# Altium schematic sheet SW6224+A+B+C+LED_普通188数码管.SchDoc
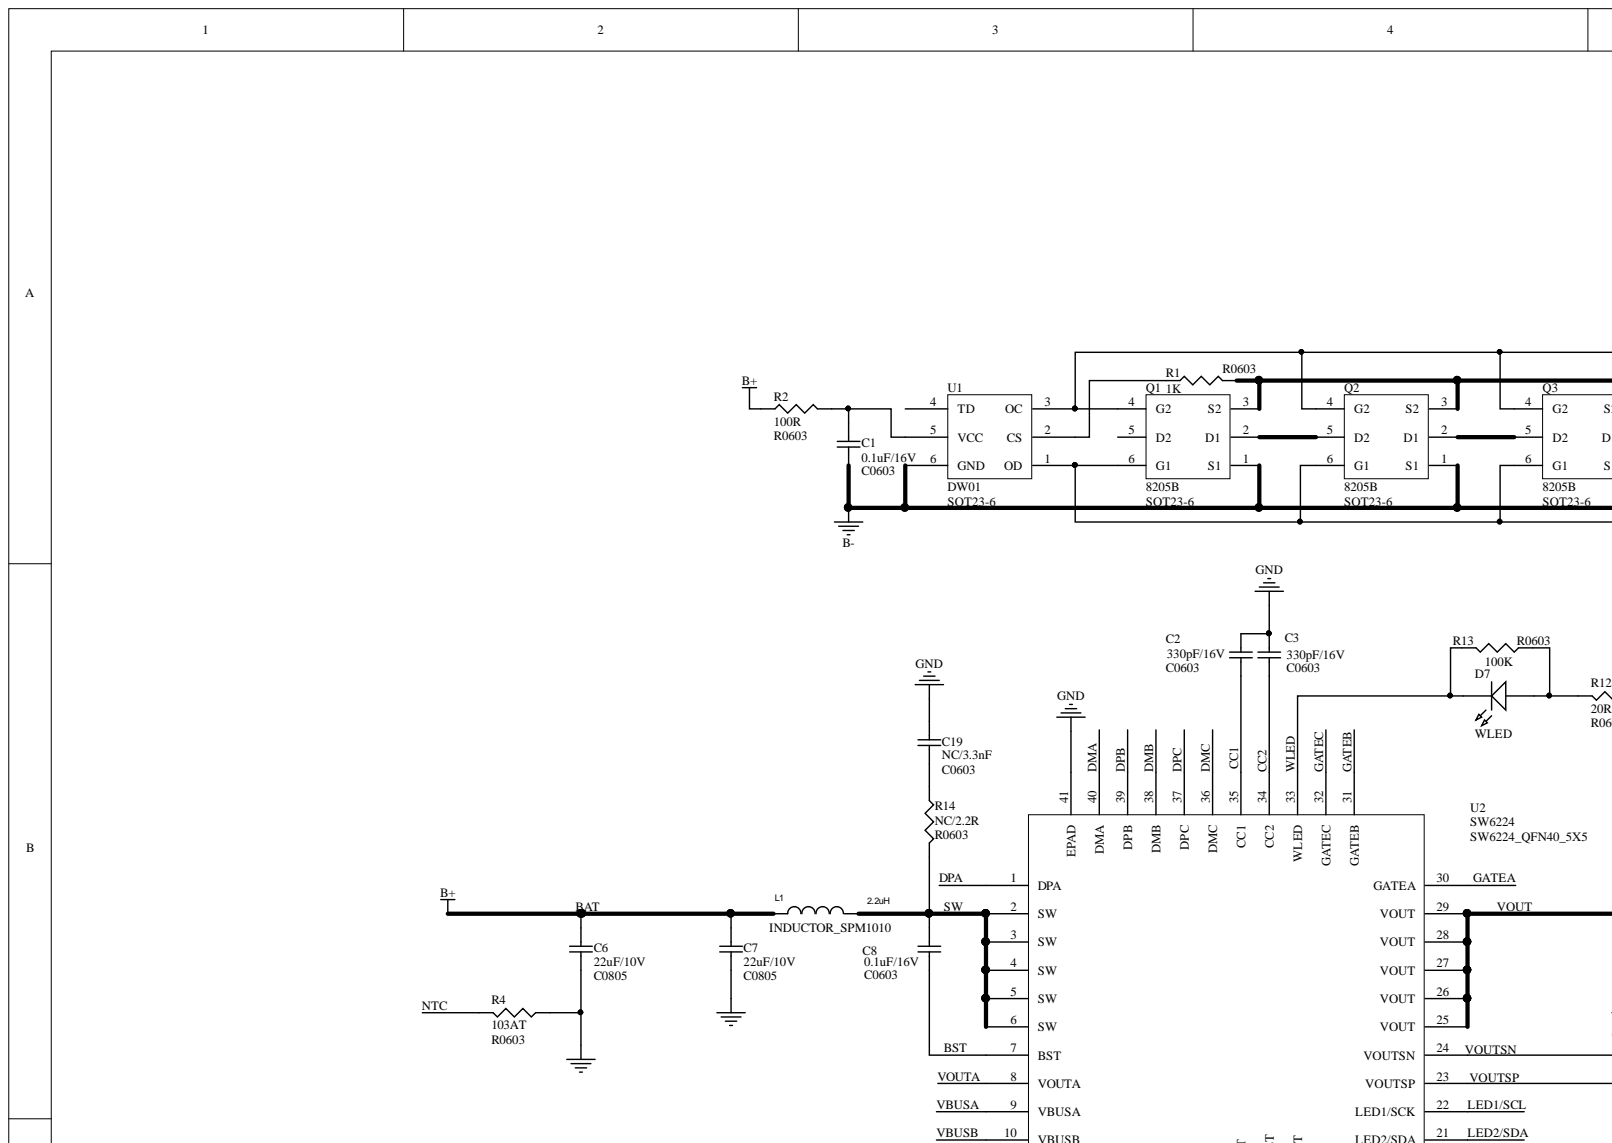
[diagram p0: SW6224+A+B+C+LED_普通188数码管.SchDoc, top left]
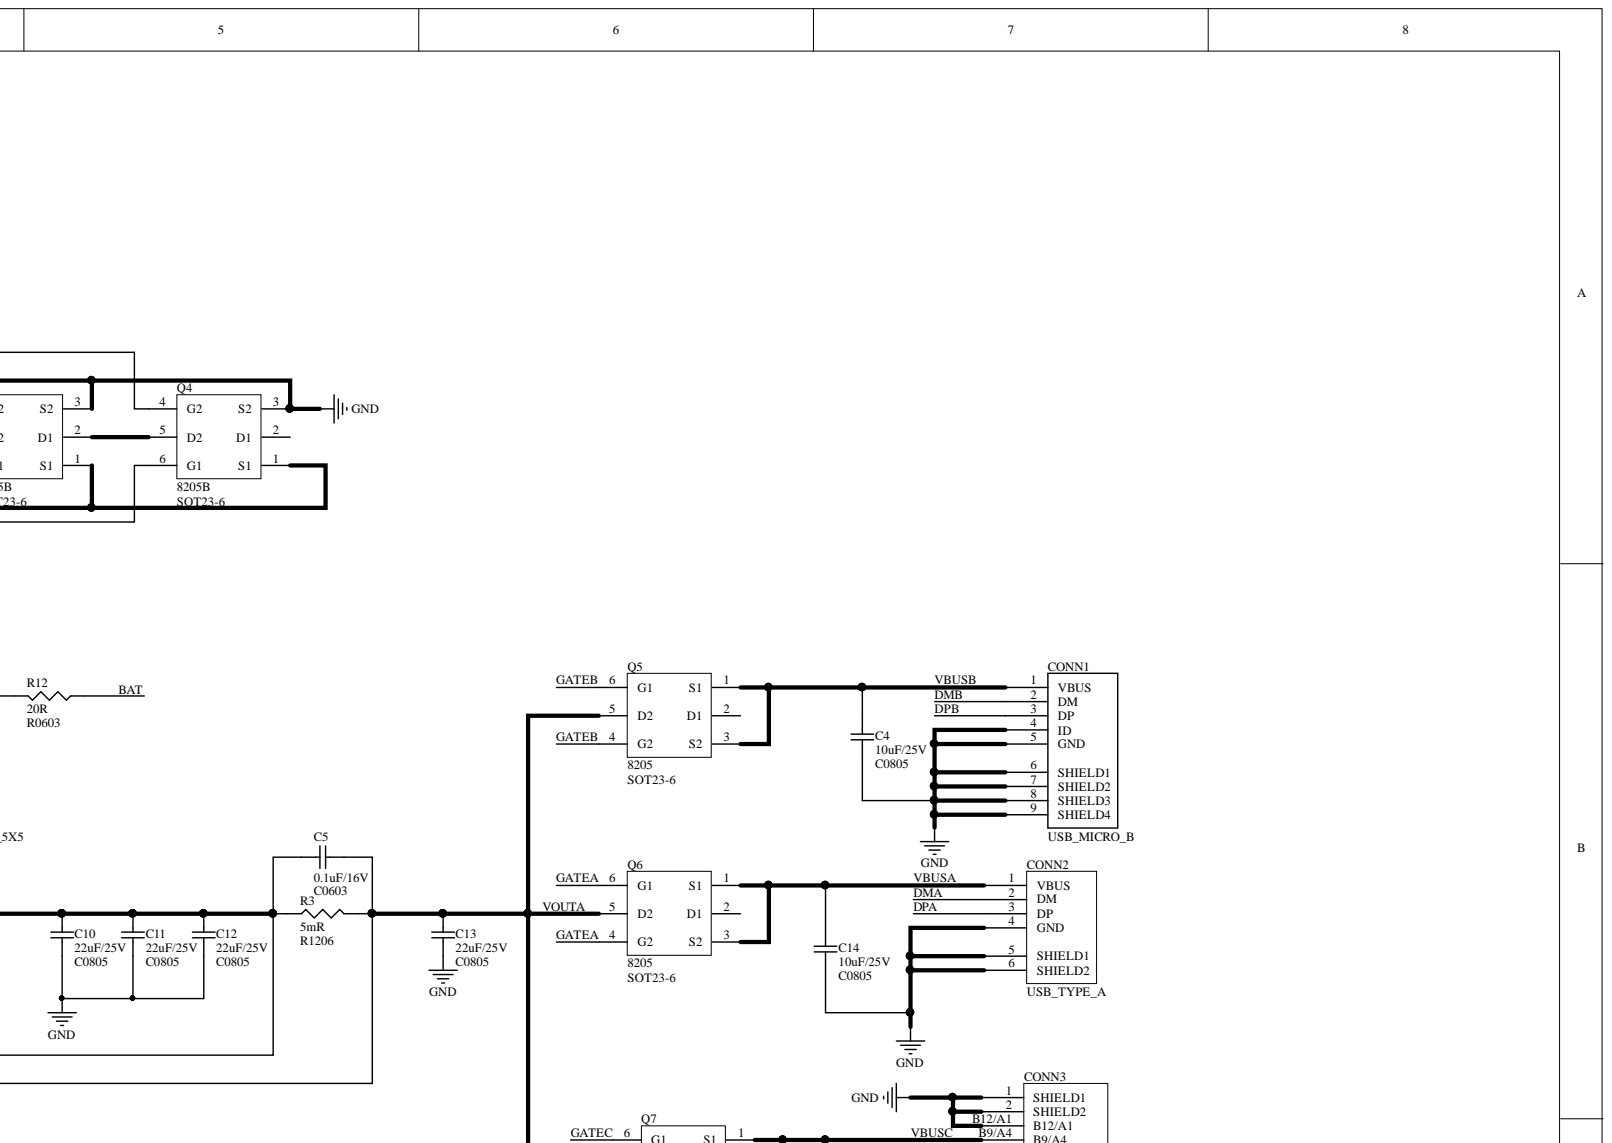
[diagram p1: SW6224+A+B+C+LED_普通188数码管.SchDoc, top right]
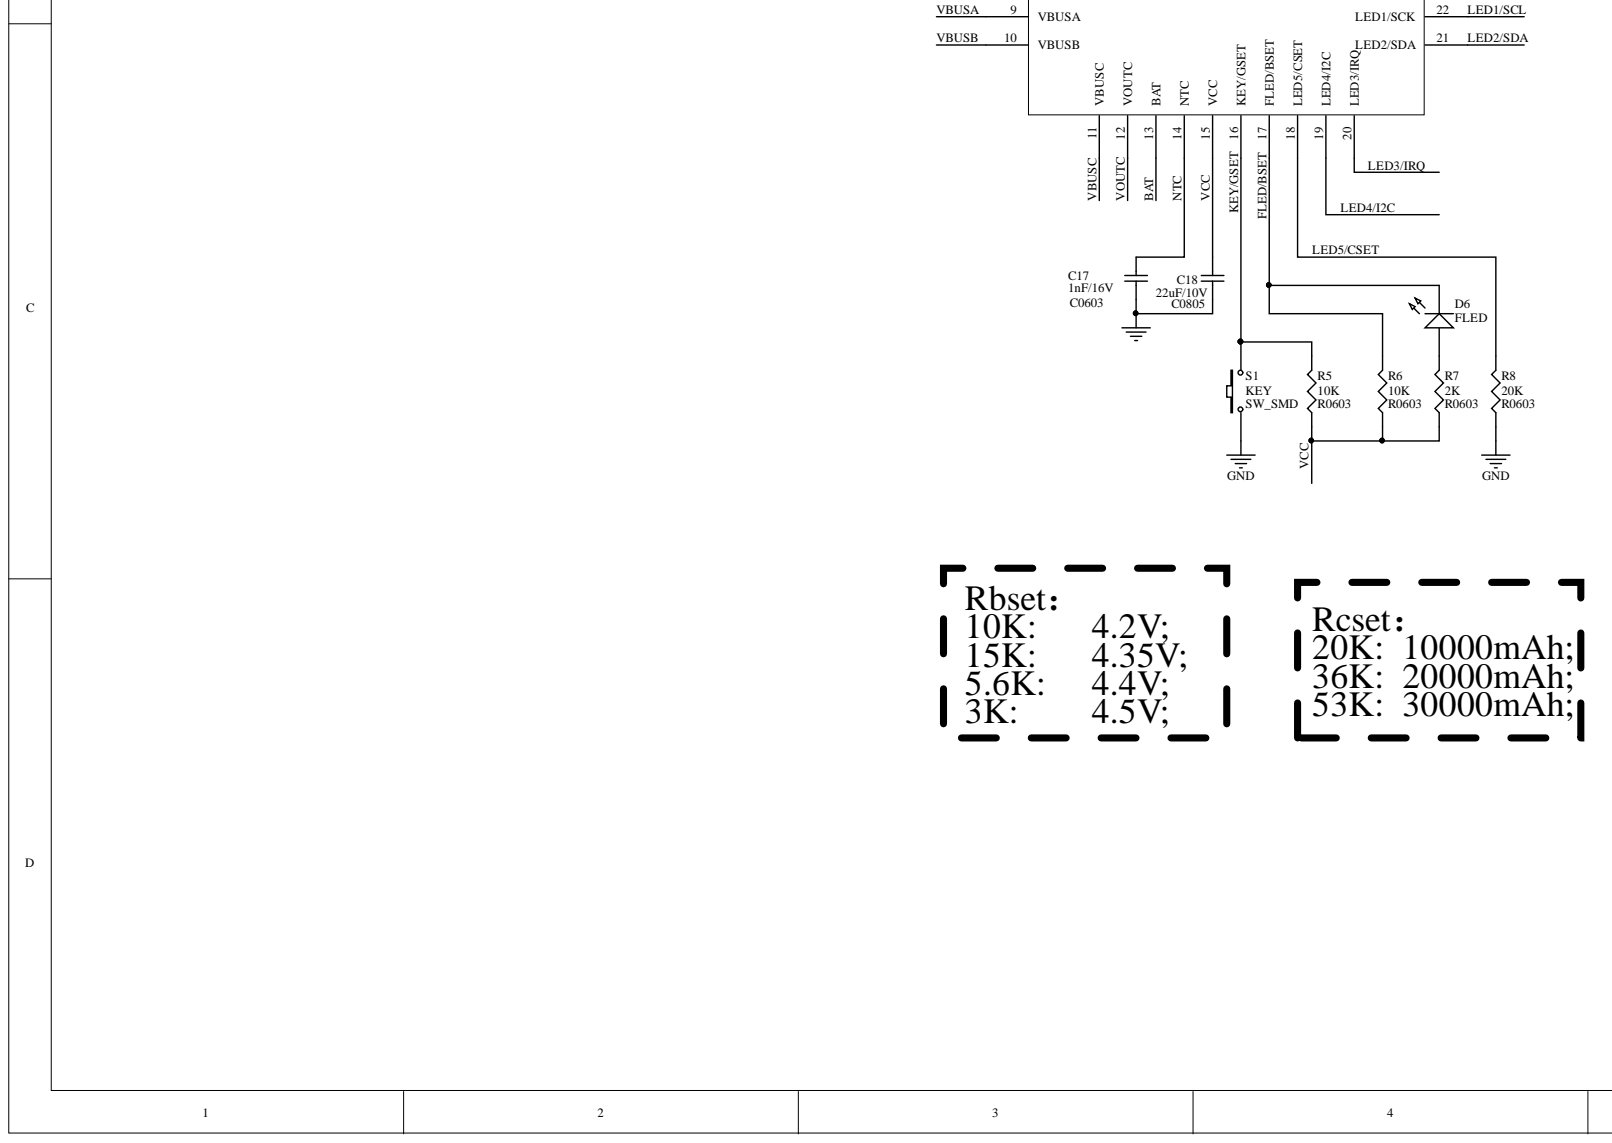
[diagram p2: SW6224+A+B+C+LED_普通188数码管.SchDoc, bottom left]
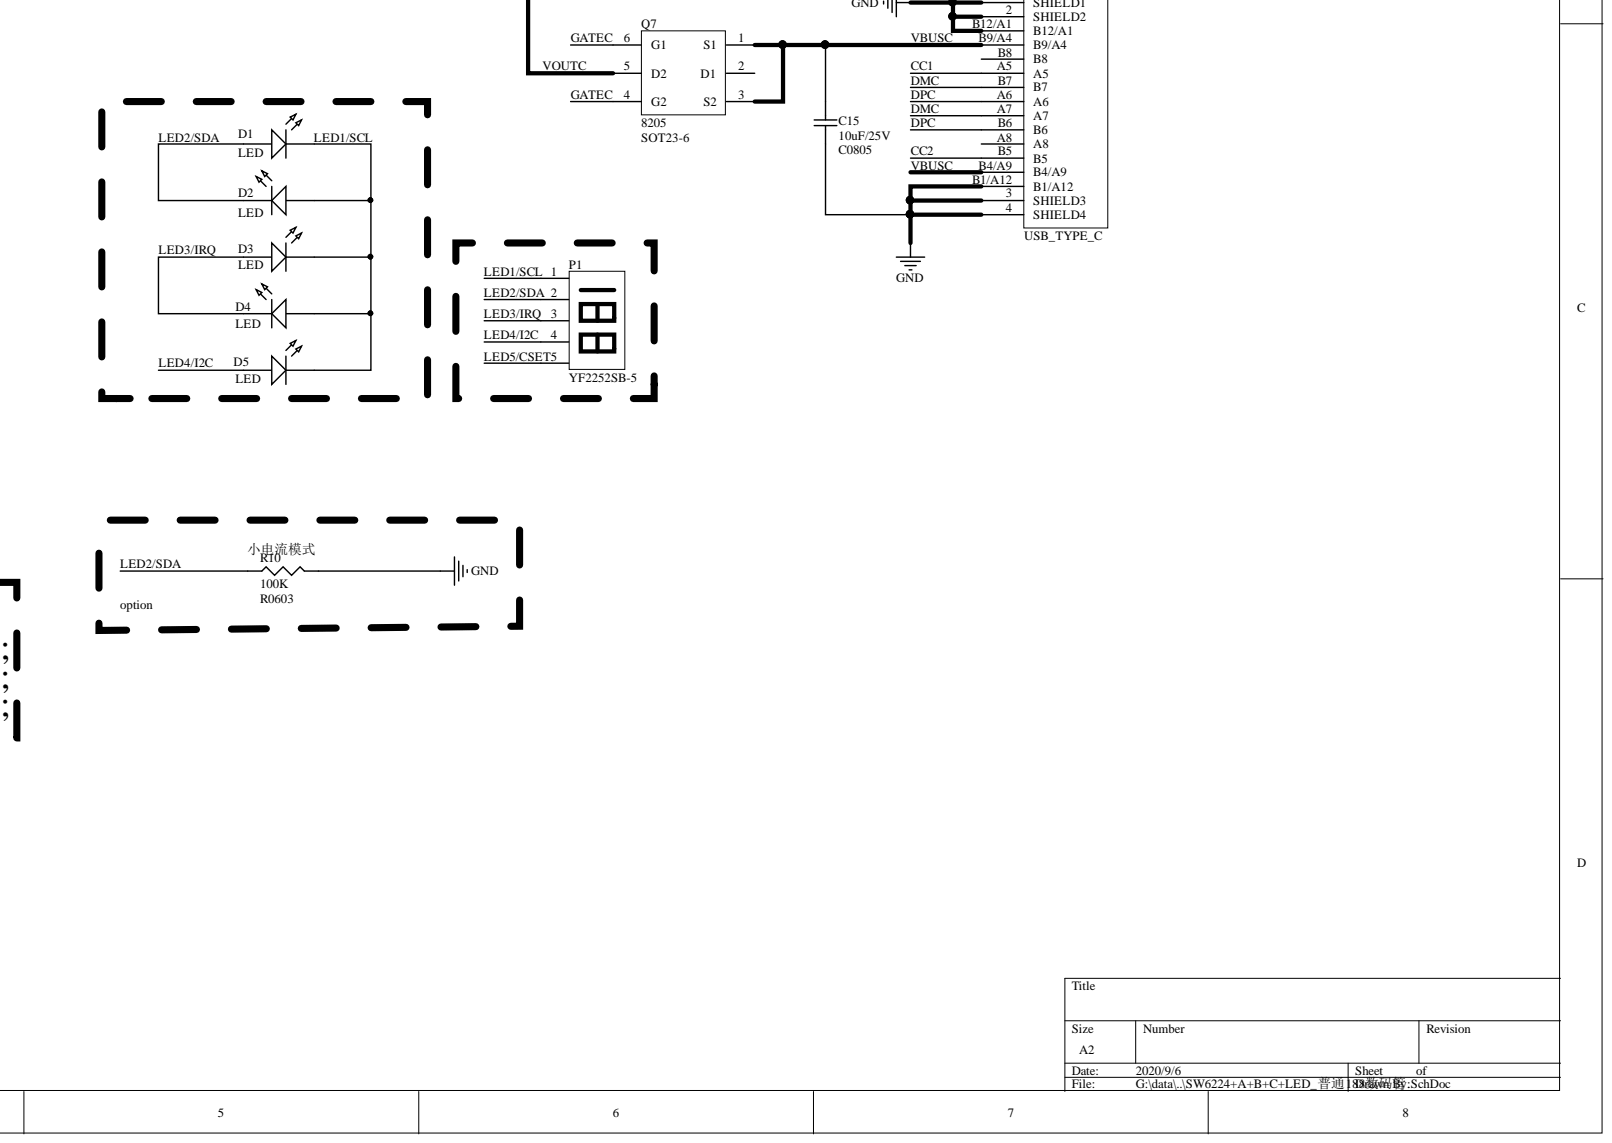
[diagram p3: SW6224+A+B+C+LED_普通188数码管.SchDoc, bottom right]

## Parts
C1  0.1uF/16V  [C0603]
C2  330pF/16V  [C0603]
C3  330pF/16V  [C0603]
C4  10uF/25V  [C0805]
C5  0.1uF/16V  [C0603]
C6  22uF/10V  [C0805]
C7  22uF/10V  [C0805]
C8  0.1uF/16V  [C0603]
C10  22uF/25V  [C0805]
C11  22uF/25V  [C0805]
C12  22uF/25V  [C0805]
C13  22uF/25V  [C0805]
C14  10uF/25V  [C0805]
C15  10uF/25V  [C0805]
C17  1nF/16V  [C0603]
C18  22uF/10V  [C0805]
C19  NC/3.3nF  [C0603]
CONN1  USB_MICRO_B  [USB_MICRO_B]
CONN2  USB_TYPE_A  [USB_TYPE_A]
CONN3  USB_TYPE_C  [USB_TYPE_C]
D1  LED  [LED0603]
D2  LED  [LED0603]
D3  LED  [LED0603]
D4  LED  [LED0603]
D5  LED  [LED0603]
D6  FLED  [LED0603]
D7  WLED  [LED_5MM]
L1  2.2uH  [INDUCTOR_SPM1010]
P1  YF2252SB-5  [LED_Display_5]
Q1  8205B  [SOT23-6]
Q2  8205B  [SOT23-6]
Q3  8205B  [SOT23-6]
Q4  8205B  [SOT23-6]
Q5  8205  [SOT23-6]
Q6  8205  [SOT23-6]
Q7  8205  [SOT23-6]
R1  1K  [R0603]
R2  100R  [R0603]
R3  5mR  [R1206]
R4  103AT  [R0603]
R5  10K  [R0603]
R6  10K  [R0603]
R7  2K  [R0603]
R8  20K  [R0603]
R10  100K  [R0603]
R12  20R  [R0603]
R13  100K  [R0603]
R14  NC/2.2R  [R0603]
S1  KEY  [SW_SMD]
U1  DW01  [SOT23-6]
U2  SW6224  [SW6224_QFN40_5X5]

## Nets
B-: C1.1 Q1.1(S1) Q2.1(S1) Q3.1(S1) Q4.1(S1) U1.6(GND)
GND: C2.2 C3.2 C4.1 C6.1 C7.1 C10.1 C11.1 C12.1 C13.1 C14.1 C15.1 C17.2 C18.2 C19.2 CONN1.4(ID) CONN1.5(GND) CONN1.6(SHIELD1) CONN1.7(SHIELD2) CONN1.8(SHIELD3) CONN1.9(SHIELD4) CONN2.4(GND) CONN2.5(SHIELD1) CONN2.6(SHIELD2) CONN3.1(SHIELD1) CONN3.2(SHIELD2) CONN3.3(SHIELD3) CONN3.4(SHIELD4) CONN3.B1/A12 CONN3.B12/A1 Q1.3(S2) Q2.3(S2) Q3.3(S2) Q4.3(S2) R1.2 R4.1 R8.1 R10.2 S1.1 U2.41(EPAD)
BAT: C6.2 C7.2 L1.1 R2.1 R12.1 U2.13(BAT)
BST: C8.1 U2.7(BST)
CC1: C2.1 CONN3.A5 U2.35(CC1)
CC2: C3.1 CONN3.B5 U2.34(CC2)
DMA: CONN2.2(DM) U2.40(DMA)
DMB: CONN1.2(DM) U2.38(DMB)
DMC: CONN3.A7 CONN3.B7 U2.36(DMC)
DPA: CONN2.3(DP) U2.1(DPA)
DPB: CONN1.3(DP) U2.39(DPB)
DPC: CONN3.A6 CONN3.B6 U2.37(DPC)
FLED/BSET: D6.2 R6.2 U2.17(FLED/BSET)
GATEA: Q6.4(G2) Q6.6(G1) U2.30(GATEA)
GATEB: Q5.4(G2) Q5.6(G1) U2.31(GATEB)
GATEC: Q7.4(G2) Q7.6(G1) U2.32(GATEC)
KEY/GSET: R5.2 S1.2 U2.16(KEY/GSET)
LED1/SCL: D1.2 D2.1 D3.2 D4.1 D5.2 P1.1 U2.22(LED1/SCK)
LED2/SDA: D1.1 D2.2 P1.2 R10.1 U2.21(LED2/SDA)
LED3/IRQ: D3.1 D4.2 P1.3 U2.20(LED3/IRQ)
LED4/I2C: D5.1 P1.4 U2.19(LED4/I2C)
LED5/CSET: P1.5 R8.2 U2.18(LED5/CSET)
NTC: C17.1 R4.2 U2.14(NTC)
SW: C8.2 L1.2 R14.2 U2.2(SW) U2.3(SW) U2.4(SW) U2.5(SW) U2.6(SW)
VBUSA: C14.2 CONN2.1(VBUS) Q6.1(S1) Q6.3(S2) U2.9(VBUSA)
VBUSB: C4.2 CONN1.1(VBUS) Q5.1(S1) Q5.3(S2) U2.10(VBUSB)
VBUSC: C15.2 CONN3.B4/A9 CONN3.B9/A4 Q7.1(S1) Q7.3(S2) U2.11(VBUSC)
VCC: C18.1 R5.1 R6.1 R7.1 U2.15(VCC)
VOUT: C5.1 C10.2 C11.2 C12.2 R3.1 U2.24(VOUTSN) U2.25(VOUT) U2.26(VOUT) U2.27(VOUT) U2.28(VOUT) U2.29(VOUT)
VOUTA: C5.2 C13.2 Q5.5(D2) Q6.5(D2) Q7.5(D2) R3.2 U2.8(VOUTA) U2.12(VOUTC) U2.23(VOUTSP)
WLED: D7.2 R13.2 U2.33(WLED)
NetC1_2: C1.2 R2.2 U1.5(VCC)
NetC19_1: C19.1 R14.1
NetD6_1: D6.1 R7.2
NetD7_1: D7.1 R12.2 R13.1
NetQ1_2: Q1.2(D1) Q2.5(D2)
NetQ1_4: Q1.4(G2) Q2.4(G2) Q3.4(G2) Q4.4(G2) U1.3(OC)
NetQ1_6: Q1.6(G1) Q2.6(G1) Q3.6(G1) Q4.6(G1) U1.1(OD)
NetQ2_2: Q2.2(D1) Q3.5(D2)
NetQ3_2: Q3.2(D1) Q4.5(D2)
NetR1_1: R1.1 U1.2(CS)
VOUTSN:
VOUTSP:

Not connected: CONN3 A8 B8; Q1 5(D2); Q4 2(D1); Q5 2(D1); Q6 2(D1); Q7 2(D1); U1 4(TD)

## Library data of the parts
C1-C3 C5 C8 C17 C19 = CAP0603: Capacitor; Value: 22uF/16V; Component Kind: Standard; LatestRevisionDate: 17-Jul-2002; LatestRevisionNote: Re-released for DXP Platform.; PackageDescription: Radial Cap, Thru-Hole; 2 Leads; 0.3 in Pin Spacing; PackageReference: RAD-0.3; Published: 8-Jun-2000; Library Name: 参考设计器件原理图更新.SchLib; Publisher: Altium Limited
C4 C6 C7 C14 C15 C18 = CAP0805: Capacitor; Value: 22uF/25V; Component Kind: Standard; LatestRevisionDate: 17-Jul-2002; LatestRevisionNote: Re-released for DXP Platform.; PackageDescription: Radial Cap, Thru-Hole; 2 Leads; 0.3 in Pin Spacing; PackageReference: RAD-0.3; Published: 8-Jun-2000; Library Name: 参考设计器件原理图更新.SchLib; Publisher: Altium Limited
C10-C13 = CAP0805: Capacitor; Component Kind: Standard; LatestRevisionDate: 17-Jul-2002; LatestRevisionNote: Re-released for DXP Platform.; PackageDescription: Radial Cap, Thru-Hole; 2 Leads; 0.3 in Pin Spacing; PackageReference: RAD-0.3; Published: 8-Jun-2000; Value: 22uF/25V; Library Name: 参考设计器件原理图更新.SchLib; Publisher: Altium Limited
D1-D5 = LED_0603: Value: FLED; Component Kind: Standard; Description: LED; LatestRevisionDate: 08-Jul-2005; LatestRevisionNote: Mech Layer 1 removed.; PackageDescription: LED; 2 Leads; PackageReference: LED-0; Published: 8-Jun-2000; Library Name: 参考设计器件原理图更新.SchLib; Publisher: Altium Limited
D6 = LED_0603: LED; Component Kind: Standard; LatestRevisionDate: 08-Jul-2005; LatestRevisionNote: Mech Layer 1 removed.; PackageDescription: LED; 2 Leads; PackageReference: LED-0; Published: 8-Jun-2000; Value: FLED; Library Name: 参考设计器件原理图更新.SchLib; Publisher: Altium Limited
D7 = WLED: Value: FLED; Component Kind: Standard; Description: WLED; LatestRevisionDate: 08-Jul-2005; LatestRevisionNote: Mech Layer 1 removed.; PackageDescription: LED; 2 Leads; PackageReference: LED-0; Published: 8-Jun-2000; Library Name: 参考设计器件原理图更新.SchLib; Publisher: Altium Limited
L1 = INDUCTOR_SPM1010: INDUCTOR; Component Kind: Standard; Value: 2.2uH; Library Name: 参考设计器件原理图更新.SchLib
P1 = LED_Display_5: 188_LED_Display; Component Kind: Standard; Library Name: 参考设计器件原理图更新.SchLib
Q1-Q4 = 8205: NMOS; Value: 8205; Component Kind: Standard; Footprinf: SOT23-6; Library Name: 参考设计器件原理图更新.SchLib
Q5-Q7 = 8205: NMOS; Component Kind: Standard; Footprinf: SOT23-6; Value: 8205; Library Name: 参考设计器件原理图更新.SchLib
R1 R2 R4 R7 R8 R10 R12-R14 = RES_0603: Resistor; Value: 10K; Component Kind: Standard; LatestRevisionDate: 29-May-2009; LatestRevisionNote: IPC-7351 Footprint Added.; PackageDescription: Chip Resistor; PackageReference: J1-0603; Published: 8-Jun-2000; Library Name: 参考设计器件原理图更新.SchLib; Publisher: Altium Limited
R3 = RES_1206: Resistor; Value: 10K; Component Kind: Standard; LatestRevisionDate: 29-May-2009; LatestRevisionNote: IPC-7351 Footprint Added.; PackageDescription: Chip Resistor; PackageReference: J1-0603; Published: 8-Jun-2000; Library Name: 参考设计器件原理图更新.SchLib; Publisher: Altium Limited
R5 R6 = RES_0603: Resistor; Component Kind: Standard; LatestRevisionDate: 29-May-2009; LatestRevisionNote: IPC-7351 Footprint Added.; PackageDescription: Chip Resistor; PackageReference: J1-0603; Published: 8-Jun-2000; Value: 10K; Library Name: 参考设计器件原理图更新.SchLib; Publisher: Altium Limited
S1 = SW K: Component Kind: Standard; Description: KEY; Footprinf: SW_SMD; Value: KEY; Library Name: 参考设计器件原理图更新.SchLib
U1 = DW01: BAT_PROTECT_IC; Component Kind: Standard; Value: DW01; Library Name: 参考设计器件原理图更新.SchLib
U2 = SW6224: IC; Component Kind: Standard; Library Name: 芯片型号原理图.SchLib
CONN1 = USB_MICRO_B: Component Kind: Standard; Description: USB_MICRO_B; Footprintf: MICRO_B; Value: USB_MICRO_B; Library Name: 参考设计器件原理图更新.SchLib
CONN2 = USB_TYPE_A: Component Kind: Standard; Description: USB_TYPE_A; Library Name: 参考设计器件原理图更新.SchLib
CONN3 = USB_TYPE_C: Component Kind: Standard; Description: USB_TYPE_C; Value: USB_TYPE_C; Library Name: 参考设计器件原理图更新.SchLib
